# Altium schematic sheet RFM98W433S2.SchDoc(RFM98W433S2)
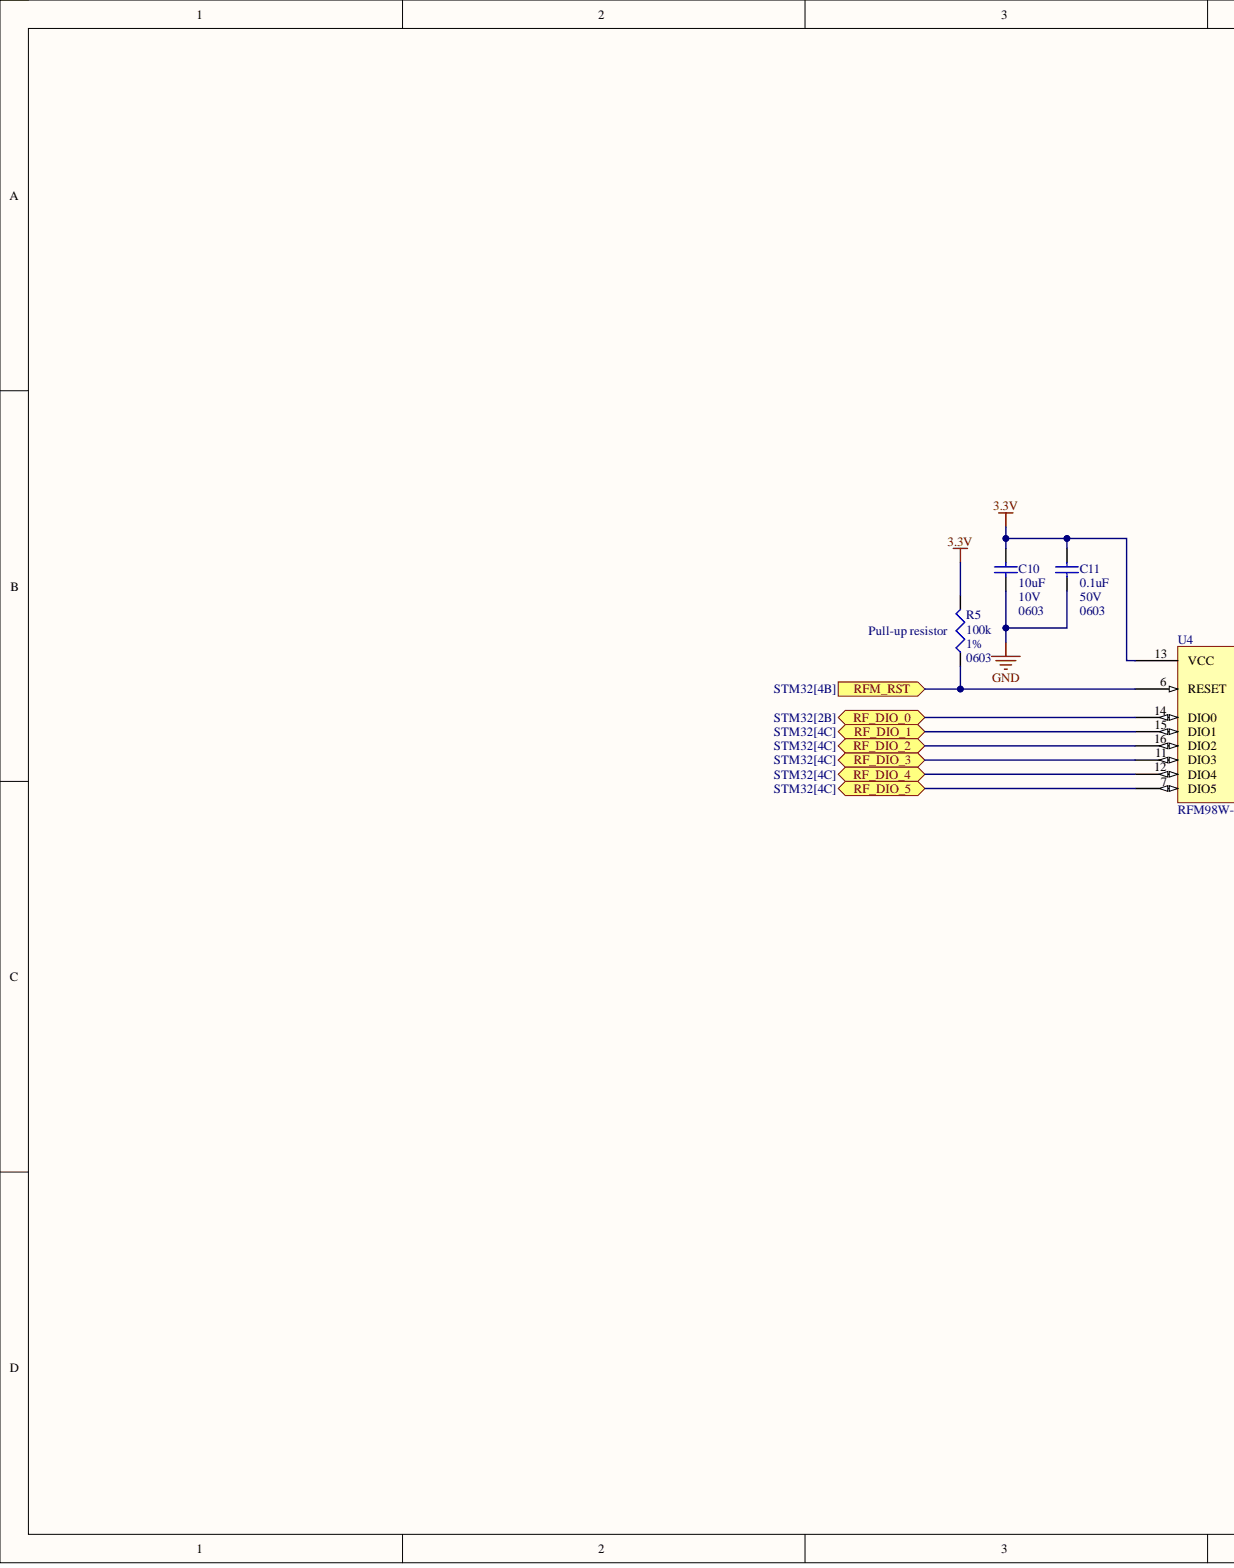
[diagram p0: RFM98W433S2.SchDoc, left]
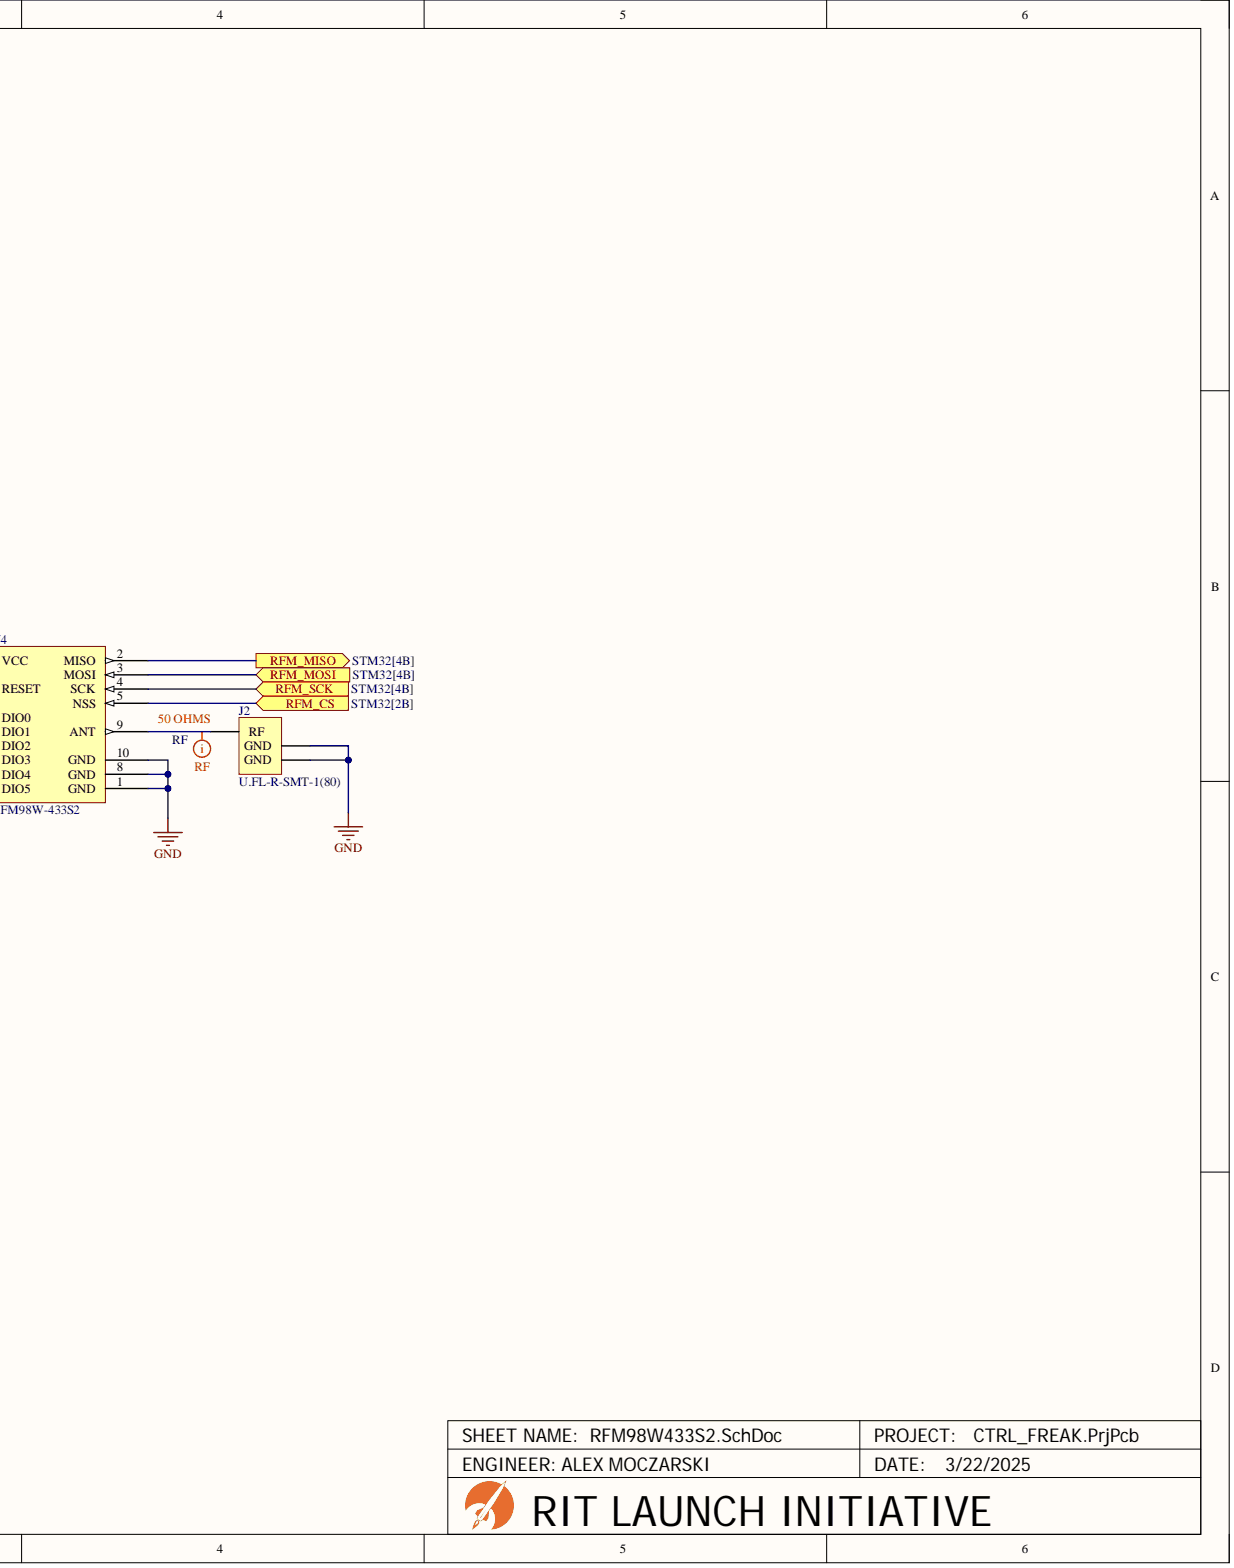
[diagram p1: RFM98W433S2.SchDoc, right]

## Parts
C10  Capacitance: 10uF  Case/Package: 0603  Voltage: 10V  (hidden comment: CL10A106KP8NNNC)
C11  Capacitance: 0.1uF  Case Code (Imperial): 0603  Voltage: 50V  (hidden comment: CC0603KRX7R9BB104)
J2  U.FL-R-SMT-1(80)
R5  Case/Package: 0603  Resistance: 100k  Tolerance: 1%  (hidden comment: 0603WAF1003T5E)
U4  RFM98W-433S2

## Ports
RF_DIO_0, RF_DIO_1, RF_DIO_2, RF_DIO_3, RF_DIO_4, RF_DIO_5, RFM_CS, RFM_MISO, RFM_MOSI, RFM_RST, RFM_SCK

## Nets
3.3V: C10.2 C11.2 R5.2 U4.13(VCC)
GND: C10.1 C11.1 J2.2 J2.3 U4.1(GND) U4.8(GND) U4.10(GND)
RF_DIO_0: U4.14(DIO0) ; port RF_DIO_0
RF_DIO_1: U4.15(DIO1) ; port RF_DIO_1
RF_DIO_2: U4.16(DIO2) ; port RF_DIO_2
RF_DIO_3: U4.11(DIO3) ; port RF_DIO_3
RF_DIO_4: U4.12(DIO4) ; port RF_DIO_4
RF_DIO_5: U4.7(DIO5) ; port RF_DIO_5
RFM_CS: U4.5(NSS) ; port RFM_CS
RFM_MISO: U4.2(MISO) ; port RFM_MISO
RFM_MOSI: U4.3(MOSI) ; port RFM_MOSI
RFM_RST: R5.1 U4.6(RESET) ; port RFM_RST
RFM_SCK: U4.4(SCK) ; port RFM_SCK
NetJ2_1: J2.1 U4.9(ANT)

## Library data of the parts
C10 = CMP-001-0266-1: CAP CER 10UF 10V X5R 0603; Material: Ceramic; Tolerance: 10%; Component Kind: Standard; Construction: Flat; Contact Plating: Tin; Depth: 0.8mm; Dielectric: X5R; Height: 0.8mm; JLCPCB Part #: C19702; Lead Free: Lead Free; Length: 1.6mm; Max Operating Temperature: 85°C; Min Operating Temperature: -55°C; Mounting Technology: Surface Mount; Package Quantity: 1; Packaging: Tape and Reel; Radiation Hardening: No; RoHS Compliant: Yes; Series: CL; Thickness: 0.035inch; Width: 0.031inch; Library Name: RIT Launch Initiative
C11 = CMP-001-0242-3: CAP CER 0.1UF 50V X7R 0603; Dielectric Material: Ceramic; Tolerance: 10%; Case Code (Metric): 1608; Case/Package: 0603; Component Kind: Standard; Depth: 0.8mm; Dielectric: X7R; Halogen Free: Halogen Free; Height: 0.8mm; JLCPCB Part #: C14663; Lead Free: Lead Free; Length: 0.063inch; Max Operating Temperature: 125°C; Min Operating Temperature: -55°C; Mount: Surface Mount; Number of Pins: 2; Package Quantity: 1; Packaging: Tape and Reel; Radiation Hardening: No; REACH SVHC: No SVHC; RoHS Compliant: Yes; Series: CC; Termination: SMD/SMT; Thickness: 0.035inch; Voltage Rating: 50V; Width: 0.031inch; Library Name: RIT Launch Initiative
J2 = CMP-001-0231-4: CONN U.FL RCPT STR 50 OHM SMD; Body Material: Bronze; Body Plating: Silver; Center Contact Plating: Gold; Connector Type: Receptacle,Male Pin; Dielectric Material: Polymer; Impedance: 50R; Mating Cycles: 30; Max Frequency: 6GHz; Number of Ports: 1; Case/Package: SMD/SMT; Component Kind: Standard; Contact Material: Brass; Fastening Type: SNAP-ON; Housing Color: Beige; JLCPCB Part #: C88374; Lead Free: Lead Free; Max Operating Temperature: 90°C; Min Operating Temperature: -40°C; Mount: Surface Mount; Package Quantity: 10000; Packaging: Tape and Reel; RoHS Compliant: Yes; Series: U.FL; Termination: Solder; Library Name: RIT Launch Initiative
R5 = CMP-001-0325-1: RES 100K OHM 1% 1/10W 0603; Component Kind: Standard; JLCPCB Part #: C25803; RoHS Compliant: Yes; Library Name: RIT Launch Initiative
U4 = CMP-00025-00005-3: Transceiver RF 433 MHz FSK/ GFSK/ MSK/ GMSK/ LoRaTM/ OOK, SMD, -148 dBm, 16 x 16 mm; Component Kind: Standard; Library Name: RIT Launch Initiative
